# Altium schematic sheet CASSA-SER-IO.SchDoc(CASSA-SER-IO)
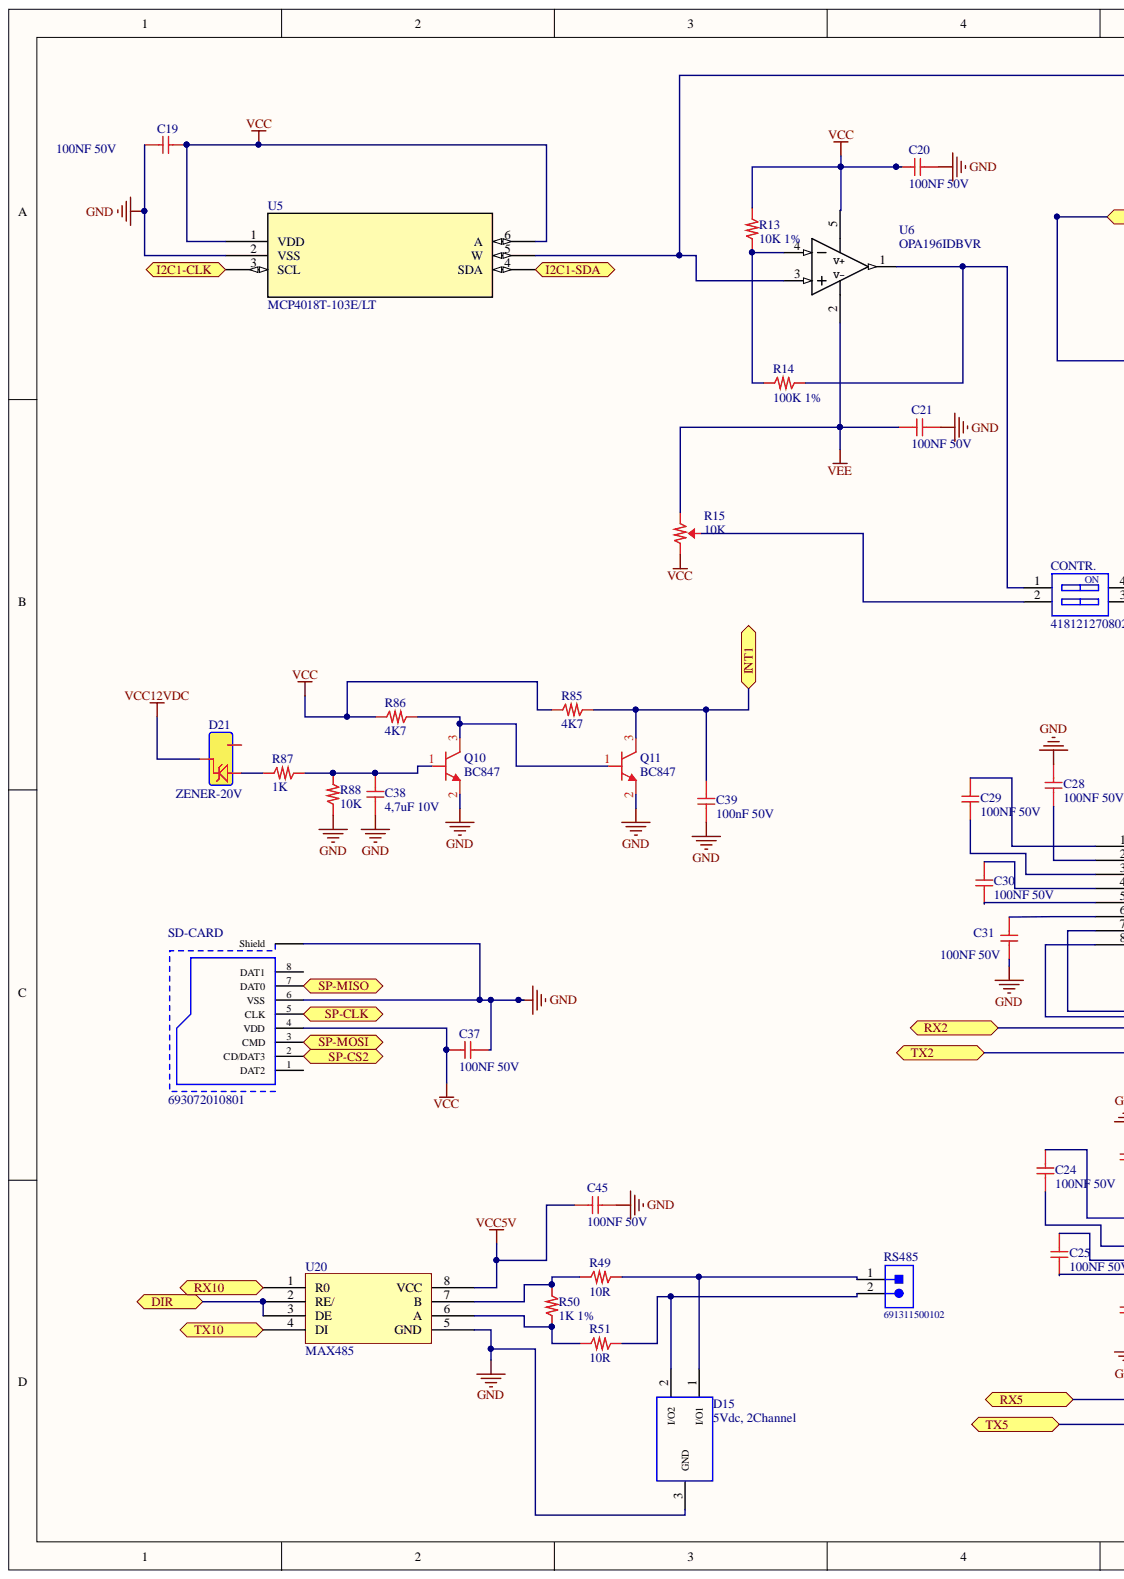
[diagram p0: CASSA-SER-IO.SchDoc, left]
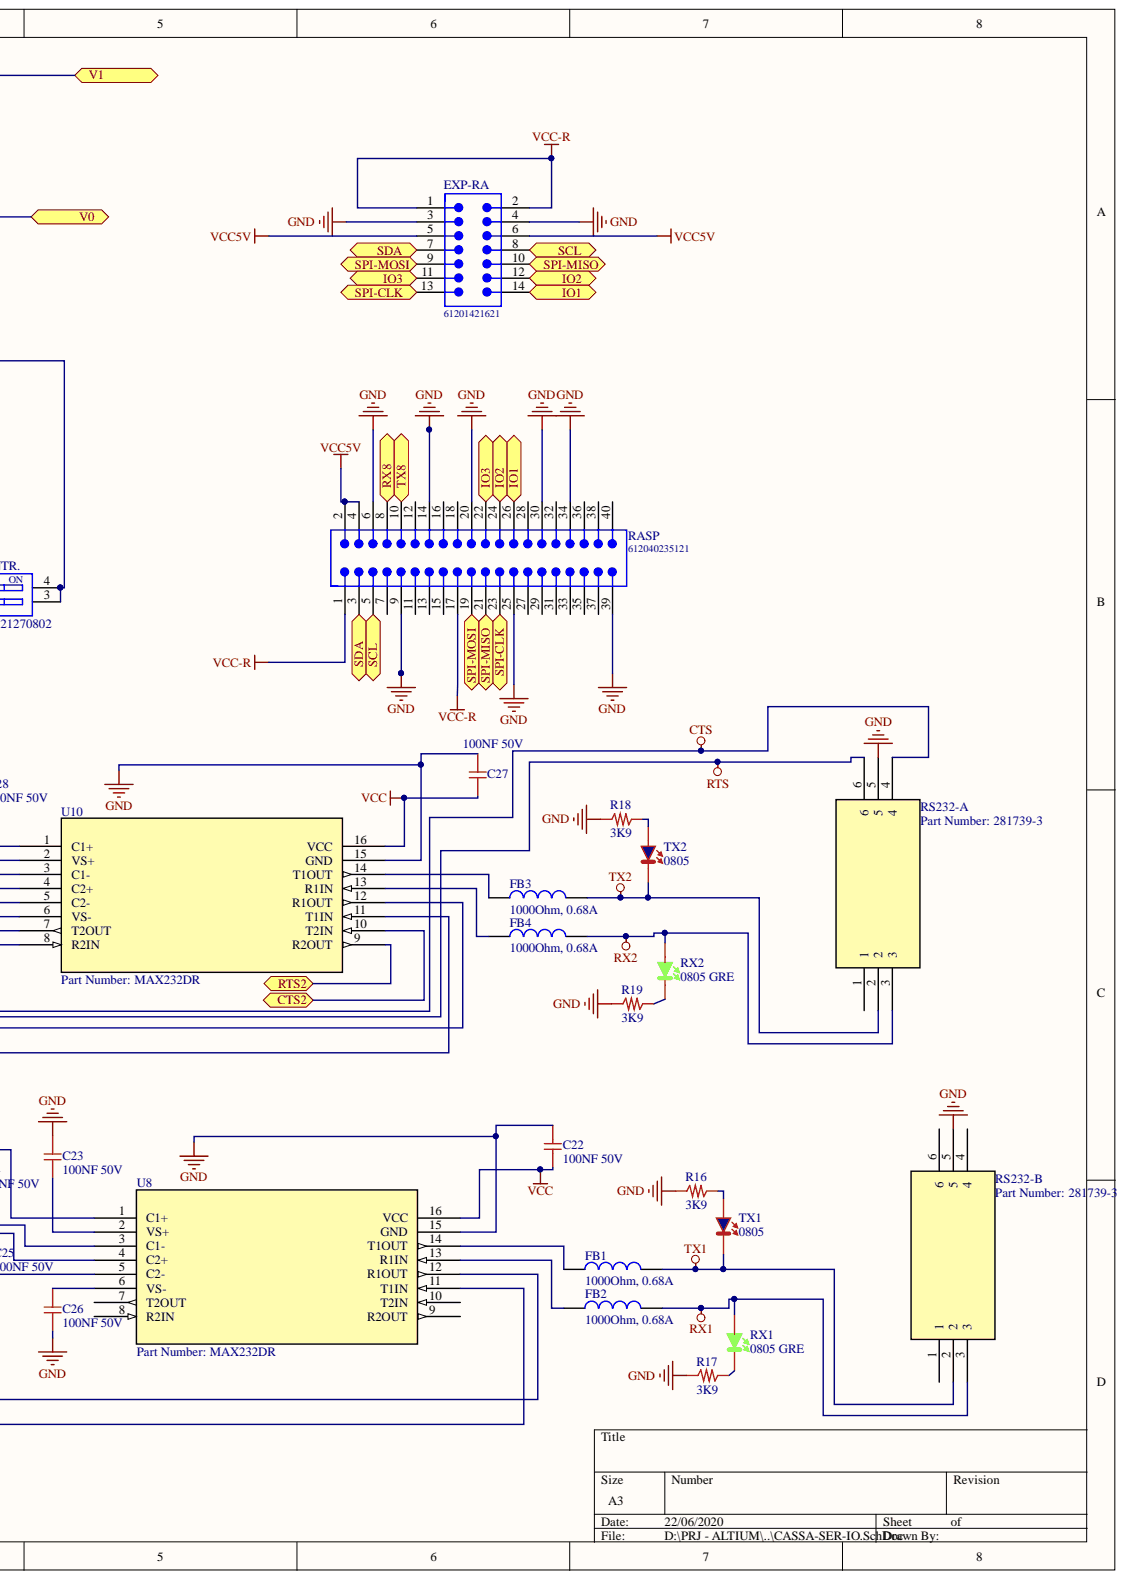
[diagram p1: CASSA-SER-IO.SchDoc, right]

## Parts
C19  100NF 50V  [0603_c]
C20  100NF 50V  [0603_c]
C21  100NF 50V  [0603_c]
C22  100NF 50V  [0603_c]
C23  100NF 50V  [0603_c]
C24  100NF 50V  [0603_c]
C25  100NF 50V  [0603_c]
C26  100NF 50V  [0603_c]
C27  100NF 50V  [0603_c]
C28  100NF 50V  [0603_c]
C29  100NF 50V  [0603_c]
C30  100NF 50V  [0603_c]
C31  100NF 50V  [0603_c]
C37  100NF 50V  [0603_c]
C38  4,7uF 10V  [0603_c]
C39  100nF 50V  [0603_c]
C45  100NF 50V  [0603_c]
CONTR.  418121270802  [418121270802]
D15  5Vdc, 2Channel  [WE-TVS_Standard_SOT23-3L]
D21  ZENER-20V  [SOT23]
EXP-RA  61201421621  [61201421621]
FB1  1000Ohm, 0.68A  Rated Current: 0.68A  [WE-CBF_0603_High Speed]
FB2  1000Ohm, 0.68A  Rated Current: 0.68A  [WE-CBF_0603_High Speed]
FB3  1000Ohm, 0.68A  Rated Current: 0.68A  [WE-CBF_0603_High Speed]
FB4  1000Ohm, 0.68A  Rated Current: 0.68A  [WE-CBF_0603_High Speed]
Q10  BC847  [SOT23]
Q11  BC847  [SOT23]
R13  10K 1%  [0603_R]
R14  100K 1%  [0603_R]
R15  10K  [ResTrimSuntan5X10X10]
R16  3K9  [0603_R]
R17  3K9  [0603_R]
R18  3K9  [0603_R]
R19  3K9  [0603_R]
R49  10R  [0805_R]
R50  1K 1%  [0603_R]
R51  10R  [0805_R]
R85  4K7  [0603_R]
R86  4K7  [0603_R]
R87  1K  [0603_R]
R88  10K  [0603_R]
RASP  612040235121  [612040235121]
RS232-A  Part Number: 281739-3  [AMP-HE14]
RS232-B  Part Number: 281739-3  [AMP-HE14]
RS485  691311500102  [691311500102]
RX1  0805 GRE  [0805_Green]
RX2  0805 GRE  [0805_Green]
SD-CARD  693072010801  [693072010801]
TX1  0805  [0805-BLU]
TX2  0805  [0805-BLU]
U5  MCP4018T-103E/LT  [SOT23-6_N]
U6  OPA196IDBVR  [DBV0005A_N]
U8  Part Number: MAX232DR  [D16]
U10  Part Number: MAX232DR  [D16]
U20  MAX485  [SO-8]

## Ports
CTS2, DIR, I2C1-CLK, I2C1-SDA, INT1, IO1, IO2, IO3, RTS2, RX2, RX5, RX8, RX10, SCL, SDA, SP-CLK, SP-CS2, SP-MISO, SP-MOSI, SPI-CLK, SPI-MISO, SPI-MOSI, TX2, TX5, TX8, TX10, V0, V1

## Nets
CTS: RS232-A.4 U10.7(T2OUT)
GND: C19.1 C20.1 C21.1 C22.1 C23.1 C26.2 C27.1 C28.1 C31.2 C37.1 C38.2 C39.2 C45.1 D15.3 EXP-RA.3 EXP-RA.4 Q10.2 Q11.2 R16.1 R17.1 R18.1 R19.1 R88.2 RASP.6 RASP.9 RASP.14 RASP.20 RASP.25 RASP.30 RASP.34 RASP.39 RS232-A.5 RS232-B.5 SD-CARD.6(VSS) SD-CARD.Shield U5.2(VSS) U8.15(GND) U10.15(GND) U20.5(GND)
RTS: RS232-A.6 U10.8(R2IN)
RX1: FB2.2 RS232-B.3 RX1.A
RX2: FB4.2 RS232-A.3 RX2.A
TX1: FB1.2 RS232-B.2 TX1.K
TX2: FB3.2 RS232-A.2 TX2.K
VCC: C19.2 C20.2 C22.2 C27.2 C37.2 R13.1 R15.3 R85.2 R86.2 SD-CARD.4(VDD) U5.1(VDD) U5.6(A) U6.5(V+) U8.16(VCC) U10.16(VCC)
VCC5V: C45.2 EXP-RA.5 EXP-RA.6 RASP.2 RASP.4 U20.8(VCC)
VCC12VDC: D21.3
VCC-R: EXP-RA.1 EXP-RA.2 RASP.1 RASP.17
VEE: C21.2 R15.1 U6.2(V-)
CTS2: U10.10(T2IN) ; port CTS2
DIR: U20.2(RE/) U20.3(DE) ; port DIR
I2C1-CLK: U5.3(SCL) ; port I2C1-CLK
I2C1-SDA: U5.4(SDA) ; port I2C1-SDA
INT1: C39.1 Q11.3 R85.1 ; port INT1
IO1: EXP-RA.14 RASP.26 ; port IO1
IO2: EXP-RA.12 RASP.24 ; port IO2
IO3: EXP-RA.11 RASP.22 ; port IO3
RTS2: U10.9(R2OUT) ; port RTS2
RX5: U8.12(R1OUT) ; port RX5
RX8: RASP.8 ; port RX8
RX10: U20.1(R0) ; port RX10
SCL: EXP-RA.8 RASP.5 ; port SCL
SDA: EXP-RA.7 RASP.3 ; port SDA
SP-CLK: SD-CARD.5(CLK) ; port SP-CLK
SP-CS2: SD-CARD.2(CD/DAT3) ; port SP-CS2
SP-MISO: SD-CARD.7(DAT0) ; port SP-MISO
SP-MOSI: SD-CARD.3(CMD) ; port SP-MOSI
SPI-CLK: EXP-RA.13 RASP.23 ; port SPI-CLK
SPI-MISO: EXP-RA.10 RASP.21 ; port SPI-MISO
SPI-MOSI: EXP-RA.9 RASP.19 ; port SPI-MOSI
TX5: U8.11(T1IN) ; port TX5
TX8: RASP.10 ; port TX8
TX10: U20.4(DI) ; port TX10
V0: CONTR..3 CONTR..4 ; port V0
V1: U5.5(W) U6.3 ; port V1
NetC23_2: C23.2 U8.2(VS+)
NetC24_1: C24.1 U8.1(C1+)
NetC24_2: C24.2 U8.3(C1-)
NetC25_1: C25.1 U8.4(C2+)
NetC25_2: C25.2 U8.5(C2-)
NetC26_1: C26.1 U8.6(VS-)
NetC28_2: C28.2 U10.2(VS+)
NetC29_1: C29.1 U10.1(C1+)
NetC29_2: C29.2 U10.3(C1-)
NetC30_1: C30.1 U10.4(C2+)
NetC30_2: C30.2 U10.5(C2-)
NetC31_1: C31.1 U10.6(VS-)
NetC38_1: C38.1 Q10.1 R87.1 R88.1
NetCONTR._1: CONTR..1 R14.2 U6.1
NetCONTR._2: CONTR..2 R15.2
NetD15_1: D15.1 R49.2 RS485.1
NetD15_2: D15.2 R51.2 RS485.2
NetD21_1: D21.1 R87.2
NetFB1_1: FB1.1 U8.14(T1OUT)
NetFB2_1: FB2.1 U8.13(R1IN)
NetFB3_1: FB3.1 U10.14(T1OUT)
NetFB4_1: FB4.1 U10.13(R1IN)
NetQ10_3: Q10.3 Q11.1 R86.1
NetR13_2: R13.2 R14.1 U6.4
NetR16_2: R16.2 TX1.A
NetR17_2: R17.2 RX1.K
NetR18_2: R18.2 TX2.A
NetR19_2: R19.2 RX2.K
NetR49_1: R49.1 R50.1 U20.7(B)
NetR50_2: R50.2 R51.1 U20.6(A)
NetU10_11: U10.11(T1IN) ; port TX2
NetU10_12: U10.12(R1OUT) ; port RX2

Not connected: D21 2; RASP 7 11 12 13 15 16 18 27 28 29 31 32 33 35 36 37 38 40; RS232-A 1; RS232-B 1 4 6; SD-CARD 1(DAT2) 8(DAT1); U8 7(T2OUT) 8(R2IN) 9(R2OUT) 10(T2IN)

## Library data of the parts
C19-C31 C37-C39 C45 = 100NF 50V: Cap X7R 100nF 50V Flex Terminal; Produttore: Samsung; Cod. Produttore: CL10B104KB8SFNC; Cod. Interno: COCS50665050104; Library Name: CapSMD0603.SchLib; Component Kind: Standard; Cod. DigiKey: 1276-1936-2-ND
CONTR. = 418121270802: SMD Dip Switch WS-DISV, Small Compact, Raised Actuator, pitch 2.54mm, 2pin; Pole Number: 2; Operation Force: 600g max.; Actuator Type: Raise Type; Published Date: April 2019; Pitch: 2.54mm; Part Number: 418121270802; Packaging: Tape and Reel; Operating Temperature: -40 to +85degC; Mounting Technology: SMT; ComponentLink2URL: https://katalog.we-online.com/em/datasheet/418121270802.pdf; ComponentLink2Description: Datasheet; ComponentLink1URL: http://www.we-online.de; ComponentLink1Description: Manufacturer; Component Kind: Standard; Publisher: Wurth Elektronik; Library Name: DIPSMD WS-DISV.SchLib
D15 = 824022: WE-TVS TVS Diode - Standard Series, SOT23-3L, 5Vdc, 2 Channel; Reverse Peak Pulse Current: 8A; Reverse Channel Leakage Current: 2.5uA; Reverse Breakdown Voltage min.: 6.1V; DC Operating Voltage: 5V; Channel Input Capacitance: 12pF; Channel: 2; Size: SOT23-3L; Published Date: June 2019; Part Number: 824022; Packaging: Tape and Reel; Operating Temperature: -55 to +125degC; Mounting Technology: SMT; Library Name: WE-TVS.SchLib; ComponentLink2URL: https://katalog.we-online.com/pbs/datasheet/824022.pdf; ComponentLink2Description: Datasheet; ComponentLink1URL: http://www.we-online.de; ComponentLink1Description: Manufacturer; Component Kind: Standard; Publisher: Wurth Elektronik
D21 = CMPZ5241B: DIODE ZENER 11V 300MW SOT23; Vz: 10.45V - 11.55V; Produttore: Central Semiconductor; Cod. Interno: ZENS 620CMPZ5241B; Component Kind: Standard; Cod. Produttore: CMPZ5241B; Library Name: DiodiZENER.SchLib
EXP-RA = 61201421621: Male Box Header WR-BHD, THT, Vertical, pitch 2.54 mm, 14 pins; Working Voltage: 250 VAC; Withstanding Voltage: 500 VAC; Pitch: 2.54 mm; Pin Number: 14; Contact Resistance: 20 mOhm; Published Date: August 2018; Part Number: 61201421621; Packaging: Tray; Orientation: Vertical; Operating Temperature: -40 to 125 degC; Mounting Technology: THT; Gender: Male; ComponentLink2URL: https://katalog.we-online.de/em/datasheet/6120xx21621.pdf; ComponentLink2Description: Datasheet; ComponentLink1URL: http://www.we-online.de; ComponentLink1Description: Manufacturer; Component Kind: Standard; Publisher: Wurth Elektronik; Library Name: CONN-FLAT.SchLib
FB1-FB4 = 742792664: WE-CBF SMT EMI Suppression Ferrite Bead, size 0603, 1000Ohm, 0.68A; Type: High Speed; Impedance max.: 1100Ohm; DC Resistance max.: 0.6Ohm; Size: 0603; Published Date: March 2019; Part Number: 742792664; Packaging: Tape and Reel; Operating Temperature: -55 to +125degC; Mounting Technology: SMT; Library Name: WE-CBF_0603.SchLib; Impedance@100 MHz: 1000Ohm; ComponentLink2URL: https://katalog.we-online.com/pbs/datasheet/742792664.pdf; ComponentLink2Description: Datasheet; ComponentLink1URL: http://www.we-online.de; ComponentLink1Description: Manufacturer; Component Kind: Standard; Publisher: Wurth Elektronik
Q10 Q11 = MMBT3904LT1G: NPN Si Digital Transistor; Vce: 40V; Vcb: 60V; Ptot: 300mW; Produttore: ON Semiconductor; Ic: 200mA; Ft: 300MHz; Cod. Interno: TRXS 110MMBT3904LT1G; Component Kind: Standard; Cod. Produttore: MMBT3904LT1G; Library Name: TransitorSMD.SCHLIB
R13 = 10K 1%: Res Film Spesso 1/16W 10K 1%; Produttore: Panasonic; Cod. Produttore: ERJ-3EKF1002V; Cod. Interno: RESS4166356B0103; Library Name: ResSMD0603.SchLib; Component Kind: Standard
R14 = 100K 1%: Res Film Spesso 1/16W 100K 1%; Produttore: ??; Cod. Interno: RESS4166360C0104; Library Name: ResSMD0603.SchLib; Component Kind: Standard; Cod. Produttore: ??
R15 = 10K POT.V: Multiturn Trimmer; Produttore: Suntan; Component Kind: Standard; Cod. Produttore: TSR-3296; Library Name: ResTrimPTH.SchLib
R16-R19 = 3K9: Res Film Spesso 1/16W 3K9 5%; Produttore: VISHAY; Cod. Produttore: CRCW06033K90JNEA; Cod. Interno: RESS4166556B0392; Library Name: ResSMD0603.SchLib; Component Kind: Standard
R49 R51 = 10R: Res Film Spesso 1/8W 10R 5%; Potenza: 1/8W 5%; Cod. Interno: RESS4170555B0100; Library Name: ResSMD0805.SchLib; Component Kind: Standard
R50 = 1K 1%: Res Film Spesso 1/16W 1K 1%; Produttore: ??; Cod. Interno: RESS4166356B0102; Library Name: ResSMD0603.SchLib; Component Kind: Standard; Cod. Produttore: ??
R85-R88 = 2K7: Res Film Spesso 1/16W 2K7 5%; Produttore: VISHAY; Cod. Produttore: CRCW06032K70JNEA; Cod. Interno: RESS4166556B0272; Library Name: ResSMD0603.SchLib; Component Kind: Standard
RASP = 612040235121: Male Box Header WR-BHD, THT, Vertical, pitch 2.54 mm, 40 pins; Working Voltage: 250 VAC; Withstanding Voltage: 500 VAC; Pitch: 2.54 mm; Pin Number: 40; Contact Resistance: 20 mOhm; Published Date: August 2018; Part Number: 612040235121; Packaging: Tray; Orientation: Vertical; Operating Temperature: -40 to 125 degC; Mounting Technology: THT; Library Name: WR-BHD_Box Headers_2.54mm.SchLib; Gender: Male; ComponentLink2URL: https://katalog.we-online.de/em/datasheet/6120xx235121.pdf; ComponentLink2Description: Datasheet; ComponentLink1URL: http://www.we-online.de; ComponentLink1Description: Manufacturer; Component Kind: Standard; Publisher: Wurth Elektronik
RS232-A RS232-B = AMP-HE14: AMP-HE-14--6PIN; Vendor: TE Connectivity; Built_By: EMA_UL_Team; Value: Value; Type: DEV; RefDes: RefDes; Manufacturer_Part_Number: 281739-3; Component Kind: Standard; Library Name: HSW.SchLib; Copyright: Copyright (C) 2018 Accelerated Designs. All rights reserved
RS485 = 691311500102: Serie 311 - 5.08mm Close Vertical PCB Header WR-TBL, 2 pin; Pin Number: 2; Gender: Male; Published Date: July 2018; Pitch: 5.08 mm; Part Number: 691311500102; Packaging: Box; Operating Temperature: -40 to 105 degC; Mounting Technology: THT; Library Name: Pluggable PCB System WR-TBL_5.08 mm.SchLib; ComponentLink2URL: http://katalog.we-online.de/em/datasheet/6913115001xx.pdf; ComponentLink2Description: Datasheet; ComponentLink1URL: http://www.we-online.de; ComponentLink1Description: Manufacturer; Component Kind: Standard; Publisher: Wurth Elektronik
RX1 RX2 = LED_Verde_0805: LED Verde 0805; V: 3.1V; Produttore: WE; Luminosità: 450mcd; Cod. Produttore: 150080GS75000; Cod. Interno: LEDS 9AC080GS7500; Component Kind: Standard; Library Name: DiodiLED.SchLib
SD-CARD = 693072010801: WR-CRD Micro SD Card Connector Hinge Type; Working Voltage: 100VAC; Withstanding Voltage: 500VAC; Rated Current: 0.5A; Pin Number: 8; Gender: Socket; Contact Resistance: 100mOhm; Type: Hinge; Published Date: November 2019; Part Number: 693072010801; Packaging: Tape and Reel; Operating Temperature: -25 to +85degC; Mounting Technology: SMT; Library Name: WR-CRD.SchLib; ComponentLink2URL: https://katalog.we-online.com/em/datasheet/693072010801.pdf; ComponentLink2Description: Datasheet; ComponentLink1URL: http://www.we-online.de; ComponentLink1Description: Manufacturer; Component Kind: Standard; Publisher: Wurth Elektronik
TX1 TX2 = LED BLU 0805: Vf(typ): 3.3V; Produttore: kingbright; Luminosità: 100mcd 20mA; Cod. Produttore: KPTR-3216QBC-D; Description: LED BLU 0805; Component Kind: Standard; Library Name: DiodiLED.SchLib
U5 = MCP4018T-103E/LT: Imported; Vendor: Microchip; C: Copyright (C) 2015 Accelerated Designs. All rights reserved; Built_By: EMA_UL_Team; Value: Value; Type: DEV; RefDes: RefDes; Manufacturer_Part_Number: MCP4018T-103E/LT; Component Kind: Standard; Library Name: HSW.SchLib
U6 = OPA196IDBVR: No Description Available; Manufacturer: Texas Instruments; Value: Value; Type: DEV; RefDes: RefDes; PartNumber: OPA196IDBVR; Component Kind: Standard; Library Name: HSW.SchLib; Copyright: Copyright (C) 2018 Accelerated Designs. All rights reserved
U8 U10 = MAX232DR: No Description Available; Vendor: Texas Instruments; Built_By: EMA_UL_Team; Value: Value; Type: DEV; RefDes: RefDes; Manufacturer_Part_Number: MAX232DR; Manufacturer: Texas Instruments; Library Name: MAX232DR.SchLib; Component Kind: Standard; Copyright: Copyright (C) 2018 Accelerated Designs. All rights reserved
U20 = MAX485: Component Kind: Standard; Library Name: HSW.SchLib
